# Altium schematic sheet [4]Button.SchDoc([4]Button)
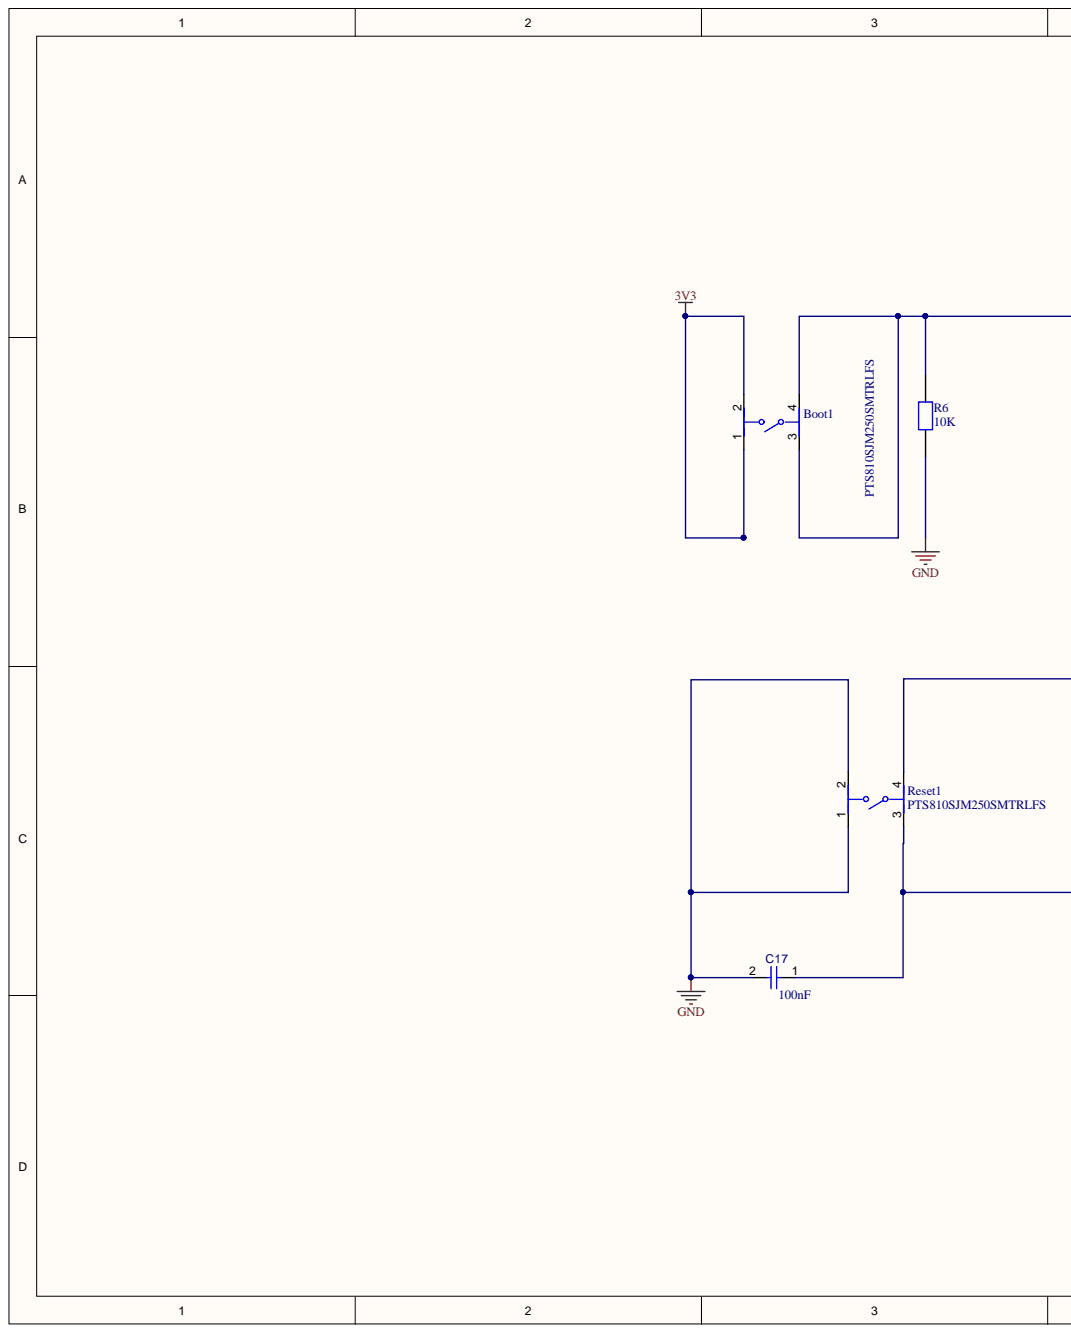
[diagram p0: [4]Button.SchDoc, left]
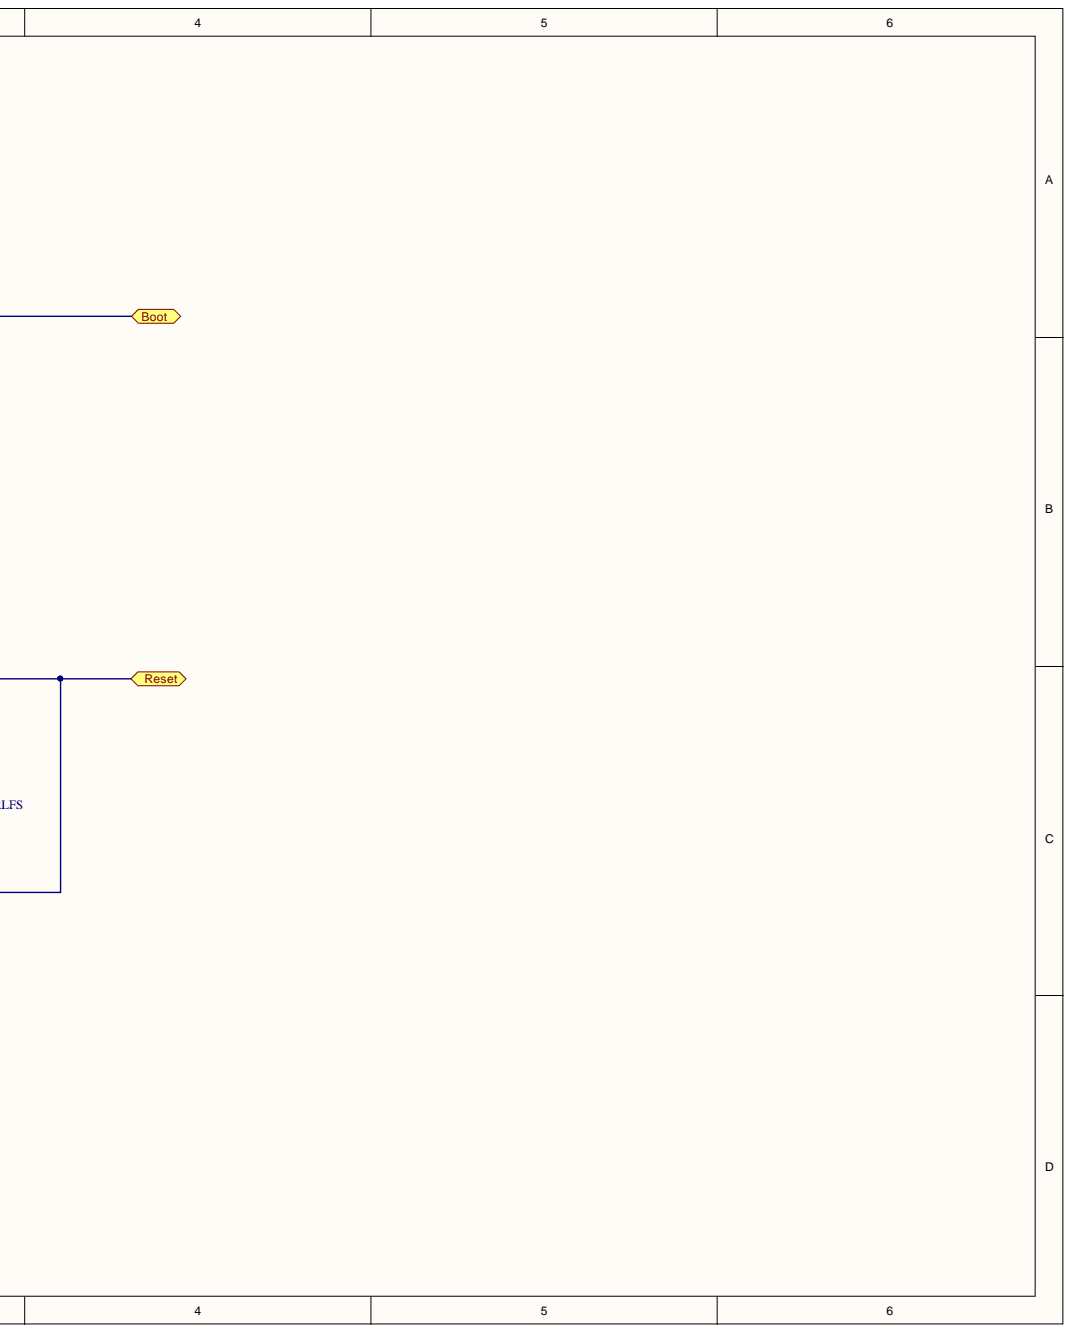
[diagram p1: [4]Button.SchDoc, right]

## Parts
Boot1  PTS810SJM250SMTRLFS  [FP-PTS810SJM250SMTRLFS-MFG]
C17  value 100nF  [CAPC1608X90X40ML10T20]  (hidden comment: Capacitor 100 nF +/-10% 50 V 0603)
R6  10K  [RESC0603]
Reset1  PTS810SJM250SMTRLFS  [FP-PTS810SJM250SMTRLFS-MFG]

## Ports
Boot, Reset

## Nets
3V3: Boot1.1 Boot1.2
GND: C17.2 R6.1 Reset1.1 Reset1.2
Boot: Boot1.3 Boot1.4 R6.2 ; port Boot
Reset: C17.1 Reset1.3 Reset1.4 ; port Reset

## Library data of the parts
Boot1 Reset1 = Button: SWITCH TACTILE SPST-NO 0.05A 16V; Manufacturer: C&K Components; Throw Configuration: SPST; Operating Force: 160gf; Electromechanical Life: 150000Cycles; Contact Resistance: 500mΩ; Contact Current Rating: 50mA; Voltage Rating Dc: 16V; Temperature Range Low: -40°C; Temperature Range High: +85°C; Temperature: -40°C to +85°C; RoHS: TRUE; Package: PTS810SJM250SMTRLFS; Octopart: https://octopart.com/pts810+sjm+250+smtr+lfs-c%26k+components-29687929; Mouser Description: Switch Tactile N.O. SPST Button J-Bend 0.05A 16VDC 150000Cycles 1.57N SMD T/R; Manufacturer Part Number: PTS810SJM250SMTRLFS; Library Name: Schlib_For_High_Voltage_Sensor.SchLib; Lead Free: Yes; Height: 2.7mm; Digikey Description: SWITCH TACTILE SPST-NO 0.05A 16V; Device Class L3: Tactile Switches; Device Class L2: Switches; Device Class L1: Electromechanical; ComponentLink2URL: https://www.ckswitches.com/media/1476/pts810.pdf; ComponentLink2Description: Datasheet; Component Kind: Standard; Category: Switch; Automotive: No
C17 = CMP-001-00017-4: Chip Capacitor, 100 nF, +/- 10%, 50 V, 0603 (1608 Metric); Voltage Rating: 50V; Capacitor Type: Ceramic; Tolerance: 10%; RoHS Compliant: True; Pins: 2; Mounting Technology: SM; Min Operating Temperature: -55°C; Max Operating Temperature: 125°C; Component Kind: Standard; Case/Package: 0603; Library Name: Latium Technologies
R6 = ERJ-PA3F1002V: RES SMD 10K OHM 1% 1/4W 0603; Manufacturer: Panasonic Electronic Components; Temperature Coefficient: ±100ppm/°C; Power (Watts): 0.25W, 1/4W; Tolerance: ±1%; Supplier Part Number 1: P10KBYDKR-ND; Supplier Device Package: 0603; Supplier 1: Digi-Key; Stock 1: 80783; Size / Dimension: 0.063" L x 0.031" W (1.60mm x 0.80mm); RoHS: RoHS Compliant; Resistance (Ohms): 10k; Pricing 1: 1=0.23, 10=0.205, 25=0.142, 50=0.1102, 100=0.0789, 250=0.06144, 500=0.04884, 1000=0.03467 (CAD); Part Status: Active; Packaging: Digi-Reel®; Package / Case: 0603 (1608 Metric); Operating Temperature: -55°C ~ 155°C; Manufacturer Part Number: ERJ-PA3F1002V; Library Name: Schlib_For_High_Voltage_Sensor.SchLib; Height - Seated (Max): 0.022" (0.55mm); Features: Automotive AEC-Q200, Pulse Withstanding; Failure Rate: -; Composition: Thick Film; ComponentLink1URL: https://industrial.panasonic.com/cdbs/www-data/pdf/RDO0000/AOA0000C291.pdf; ComponentLink1Description: https://industrial.panasonic.com/cdbs/www-data/pdf/RDO0000/AOA0000C291.pdf; Component Kind: Standard; Category: Resistors
